# Altium schematic sheet MCU_Power_and_RF_Section.SchDoc
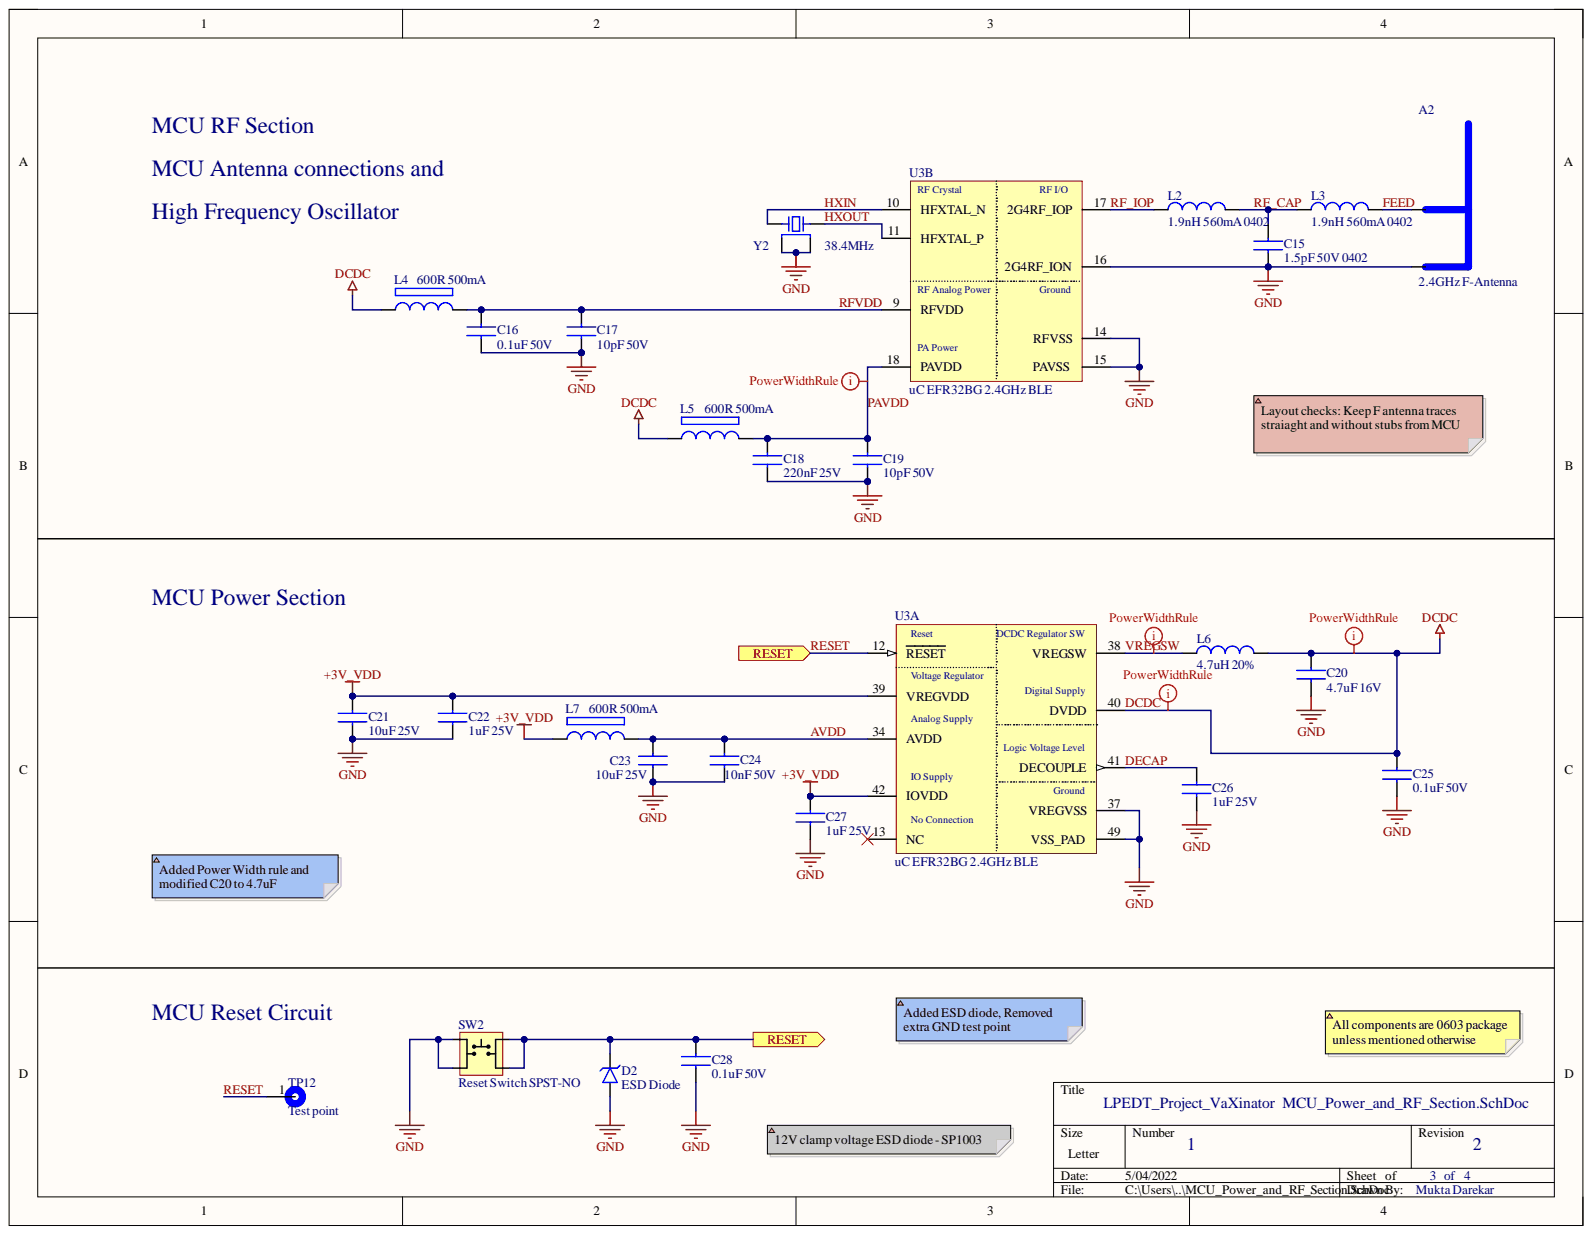
[diagram p0: MCU_Power_and_RF_Section.SchDoc]

## Parts
A2  2.4GHz F-Antenna  [F_ANT_FOOTPRINT]
C15  1.5pF 50V 0402  Capacitance: 1.5pF  Case Code (Imperial): 0402  [CAP_0402_1MM]
C16  0.1uF 50V  [CAP_0603_1608X09N]
C17  10pF 50V  [CAP_0603_1608X09N]
C18  220nF 25V  Capacitance: 220nF  [CAP_0603_1608X09N]
C19  10pF 50V  [CAP_0603_1608X09N]
C20  4.7uF 16V  Capacitance: 4.7uF  [CAP_0603_1608X09N]
C21  10uF 25V  [CAP_0603_1608X09N]
C22  1uF 25V  Capacitance: 1uF  Voltage Rating: 25V  [CAP_0603_1608X09N]
C23  10uF 25V  [CAP_0603_1608X09N]
C24  10nF 50V  Capacitance: 10nF  Voltage Rating: 50V  [CAP_0603_1608X09N]
C25  0.1uF 50V  [CAP_0603_1608X09N]
C26  1uF 25V  Capacitance: 1uF  Voltage Rating: 25V  [CAP_0603_1608X09N]
C27  1uF 25V  Capacitance: 1uF  [CAP_0603_1608X09N]
C28  0.1uF 50V  [CAP_0603_1608X09N]
D2  ESD Diode  [SOD882_DIODE_0402]
L2  1.9nH 560mA 0402  Case Code (Imperial): 0402  Max Current Rating: 560mA  [IND_RESC1005X35N]
L3  1.9nH 560mA 0402  Case Code (Imperial): 0402  Max Current Rating: 560mA  [IND_RESC1005X35N]
L4  600R 500mA  Current Rating: 500mA  Impedance: 600R  [BEADC1608X95N]
L5  600R 500mA  Current Rating: 500mA  Impedance: 600R  [BEADC1608X95N]
L6  4.7uH 20%  Inductance: 4.7uH  Tolerance: 20%  [INDC3030X15N]
L7  600R 500mA  Current Rating: 500mA  Impedance: 600R  [BEADC1608X95N]
SW2  Reset Switch SPST-NO  [TACT_SW_4PIN_SPST_450X450]
TP12  Test point  [TEST_POINT]  (no BOM)
U3  uC EFR32BG 2.4GHz BLE  [QFN48P700X700X90]  (parts A,B)
Y2  38.4MHz  [XTAL_CX2016DB16000D0WZRC1]

## Ports
RESET

## Nets
+3V_VDD: C21.1 C22.1 C27.1 L7.1 U3.39(VREGVDD) U3.42(IOVDD)
GND: A2.GND C15.2 C16.2 C17.2 C18.2 C19.1 C20.2 C21.2 C22.2 C23.2 C24.2 C25.2 C26.2 C27.2 C28.2 D2.2 SW2.1 SW2.4 U3.14(RFVSS) U3.15(PAVSS) U3.16(2G4RF_ION) U3.37(VREGVSS) U3.49(VSS_PAD) Y2.2 Y2.4
AVDD: C23.1 C24.1 L7.2 U3.34(AVDD)
DCDC: C20.1 C25.1 L4.1 L5.1 L6.2 U3.40(DVDD)
DECAP: C26.1 U3.41(DECOUPLE)
FEED: A2.FEED L3.2
HXIN: U3.10(HFXTAL_N) Y2.1
HXOUT: U3.11(HFXTAL_P) Y2.3
PAVDD: C18.1 C19.2 L5.2 U3.18(PAVDD)
RESET: C28.1 D2.1 SW2.2 SW2.3 TP12.1 U3.12(RESET) ; port RESET
RF_CAP: C15.1 L2.2 L3.1
RF_IOP: L2.1 U3.17(2G4RF_IOP)
RFVDD: C16.1 C17.1 L4.2 U3.9(RFVDD)
VREGSW: L6.1 U3.38(VREGSW)

Not connected: U3 13(NC)

## Library data of the parts
A2 = F_ANTENAA: Antenna; Component Kind: Standard; Library Name: LPEDT_Project.SchLib
C15 = GCM1555C1H1R5BA16D: CAP CER 1.5PF 50V 125C  0402 Cap Ceramic 1.5pF 50V C0G 0.1pF Pad SMD 0402 125C Automotive T/R; Manufacturer: Murata; Case Code (Metric): 1005; Case/Package: 0402; Category: Ceramic Capacitors; Component Kind: Standard; ComponentLink1Description: http://search.murata.co.jp/Ceramy/image/img/A01X/G101/ENG/GCM1555C1H1R5BA16-01.pdf?src-supplier=Digi-Key; ComponentLink1URL: http://search.murata.co.jp/Ceramy/image/img/A01X/G101/ENG/GCM1555C1H1R5BA16-01.pdf?src-supplier=Digi-Key; ComponentLink2Description: http://search.murata.co.jp/Ceramy/image/img/A01X/partnumbering_e_02.pdf?src-supplier=Digi-Key; ComponentLink2URL: http://search.murata.co.jp/Ceramy/image/img/A01X/partnumbering_e_02.pdf?src-supplier=Digi-Key; ComponentLink3Description: https://search.murata.co.jp/Ceramy/image/img/A01X/G101/ENG/GCM1555C1H1R5BA16-01.pdf?src-supplier=Digi-Key; ComponentLink3URL: https://search.murata.co.jp/Ceramy/image/img/A01X/G101/ENG/GCM1555C1H1R5BA16-01.pdf?src-supplier=Digi-Key; Library Name: LPEDT_Project.SchLib; Manufacturer Part Number: GCM1555C1H1R5BA16D; Max Operating Temperature: 125°C; Min Operating Temperature: -55°C; Mount: Surface Mount; Packaging: Tape and Reel; Pricing 1: 1=0.02300, 10000=0.02300 (USD); Ratings: AEC-Q200; RoHS: No; RoHS Compliant: No; Stock 1: 10000; Supplier 1: Newark; Voltage Rating: 50V
C16 C25 C28 = C0603C104M5RACTU: CAP CER 0.1UF 50V X7R 0603 KEMET; Capacitance: 100nF; Dielectric Material: Ceramic; Dissipation Factor: 2.5%; Tolerance: 20%; Case Code (Imperial): 0603; Case Code (Metric): 1608; Case/Package: 0603; Category: Ceramic Capacitors; Component Kind: Standard; ComponentLink1Description: http://components.arrow.com/part/dataview/40911037S8853915N7429?src-supplier=Arrow+Electronics; ComponentLink1URL: http://components.arrow.com/part/dataview/40911037S8853915N7429?src-supplier=Arrow+Electronics; ComponentLink2Description: https://www.verical.com/server-webapp/html/getDatasheet?partID=626892&src-supplier=Verical; ComponentLink2URL: https://www.verical.com/server-webapp/html/getDatasheet?partID=626892&src-supplier=Verical; ComponentLink3Description: http://www.farnell.com/datasheets/2746056.pdf?src-supplier=Farnell; ComponentLink3URL: http://www.farnell.com/datasheets/2746056.pdf?src-supplier=Farnell; ComponentLink4Description: http://components.arrow.com/part/dataview/0323_00313986?src-supplier=Arrow+Europe; ComponentLink4URL: http://components.arrow.com/part/dataview/0323_00313986?src-supplier=Arrow+Europe; ComponentLink5Description: http://www.mouser.com/ds/2/212/KEM_C1002_X7R_SMD-1102033.pdf?src-supplier=Mouser; ComponentLink5URL: http://www.mouser.com/ds/2/212/KEM_C1002_X7R_SMD-1102033.pdf?src-supplier=Mouser; ComponentLink6Description: http://www.alliedelec.com/m/d/6f3b5eb83d09db50ed28bdc730567b33.pdf?src-supplier=Allied+Electronics; ComponentLink6URL: http://www.alliedelec.com/m/d/6f3b5eb83d09db50ed28bdc730567b33.pdf?src-supplier=Allied+Electronics; ComponentLink7Description: http://www.farnell.com/datasheets/1828800.pdf?src-supplier=Newark; ComponentLink7URL: http://www.farnell.com/datasheets/1828800.pdf?src-supplier=Newark; ComponentLink8Description: http://capacitoredge.kemet.com/capedge2/DataSheet?pn=C0603C104M5RAC7867&src-supplier=Avnet; ComponentLink8URL: http://capacitoredge.kemet.com/capedge2/DataSheet?pn=C0603C104M5RAC7867&src-supplier=Avnet; ComponentLink9Description: http://www.farnell.com/datasheets/2315113.pdf?src-supplier=Newark; ComponentLink9URL: http://www.farnell.com/datasheets/2315113.pdf?src-supplier=Newark; ComponentLink10Description: https://api.kemet.com/component-edge/download/datasheet/C0603C104M5RACTU.pdf?src-supplier=Digi-Key; ComponentLink10URL: https://api.kemet.com/component-edge/download/datasheet/C0603C104M5RACTU.pdf?src-supplier=Digi-Key; ComponentLink11Description: https://www.verical.com/server-webapp/html/getDatasheet?partID=110138&src-supplier=Verical; ComponentLink11URL: https://www.verical.com/server-webapp/html/getDatasheet?partID=110138&src-supplier=Verical; ComponentLink12Description: http://www.farnell.com/datasheets/2746056.pdf?src-supplier=Element14; ComponentLink12URL: http://www.farnell.com/datasheets/2746056.pdf?src-supplier=Element14; ComponentLink13Description: http://www.farnell.com/datasheets/2746056.pdf?src-supplier=Newark; ComponentLink13URL: http://www.farnell.com/datasheets/2746056.pdf?src-supplier=Newark; ComponentLink14Description: http://capacitoredge.kemet.com/capedge2/DataSheet?pn=C0603C104M5RAC7867&src-supplier=Avnet+Asia; ComponentLink14URL: http://capacitoredge.kemet.com/capedge2/DataSheet?pn=C0603C104M5RAC7867&src-supplier=Avnet+Asia; Construction: Flat; Contact Plating: Tin,Matte; Depth: 0.8mm; Dielectric: X7R; Height: 0.8mm; Lead Pitch: 0.7mm; Length: 1.6mm; Library Name: LPEDT_Project_lib.IntLib; Manufacturer: KEMET; Manufacturer Part Number: C0603C104M5RACTU; Max Operating Temperature: 125°C; Min Operating Temperature: -55°C; Mount: Surface Mount,PCB; Package Quantity: 1; Packaging: Tape and Reel; Pricing 1: 1=0.10000, 10=0.03400, 50=0.01840, 100=0.01510, 500=0.01078, 1000=0.00847 (USD); Radiation Hardening: No; REACH SVHC: No SVHC; RoHS: Yes; Series: C; Supplier 1: Digi-Key; Termination: SMD/SMT; Thickness: 0.034inch; Voltage Rating: 50V; Voltage Rating (DC): 50V; Width: 0.031inch
C17 C19 = C0603C100J5RAC7867: CAP CER 10pF 50V X7R 0603 KEMET; Category: Ceramic Capacitors; Component Kind: Standard; Library Name: LPEDT_Project.SchLib; Manufacturer: KEMET; Manufacturer Part Number: C0603C100J5RAC7867; Pricing 1: 1=0.25000, 10=0.17400, 50=0.11580, 100=0.09840, 500=0.06944, 1000=0.06075 (USD); RoHS: Yes; RoHS Compliant: Yes; Supplier 1: Digi-Key
C18 = C0603C224K3RACAUTO: CAP CER 220nF (0.22uF) 25V X7R 0603 KEMET; Dielectric Material: Ceramic; Tolerance: 10%; Case Code (Imperial): 0603; Case Code (Metric): 1608; Case/Package: 0603; Category: Ceramic Capacitors; Component Kind: Standard; ComponentLink1Description: https://datasheet.ciiva.com/6254/datasheetpn-c0603c224k3racauto-6254707.pdf?src-supplier=Digi-Key; ComponentLink1URL: https://datasheet.ciiva.com/6254/datasheetpn-c0603c224k3racauto-6254707.pdf?src-supplier=Digi-Key; ComponentLink2Description: https://datasheet.ciiva.com/26948/getdatasheetpartid-785715-26948447.pdf?src-supplier=Verical; ComponentLink2URL: https://datasheet.ciiva.com/26948/getdatasheetpartid-785715-26948447.pdf?src-supplier=Verical; ComponentLink3Description: https://datasheet.ciiva.com/22699/1633156-22699737.pdf?src-supplier=Element14; ComponentLink3URL: https://datasheet.ciiva.com/22699/1633156-22699737.pdf?src-supplier=Element14; Contact Plating: Tin,Matte; Depth: 0.8mm; Dielectric: X7R; Length: 1.6mm; Library Name: LPEDT_Project_lib.IntLib; Manufacturer: KEMET; Manufacturer Part Number: C0603C224K3RACAUTO; Max Operating Temperature: 125°C; Min Operating Temperature: -55°C; Mount: Surface Mount; Package Quantity: 1; Packaging: Tape and Reel; Pricing 1: 1=0.19000, 10=0.13200, 50=0.07920, 100=0.06690, 500=0.04576, 1000=0.04048 (USD); Radiation Hardening: No; Ratings: AEC-Q200; REACH SVHC: No SVHC; RoHS: Yes; RoHS Compliant: Yes; Series: C; Stock 1: 7450; Supplier 1: Digi-Key; Termination: Wraparound; Thickness: 0.034inch; Voltage Rating: 25V; Voltage Rating (DC): 25V; Width: 0.031inch
C20 = GRM188R61C475KE11D: CAP CER 4.7UF 16V X5R 0603 MURATA; Tolerance: 10%; Case Code (Imperial): 0603; Case Code (Metric): 1608; Case/Package: 0603; Category: Ceramic Capacitors; Component Kind: Standard; ComponentLink1Description: http://www.mouser.com/ds/2/281/c02e-2905.pdf?src-supplier=Mouser; ComponentLink1URL: http://www.mouser.com/ds/2/281/c02e-2905.pdf?src-supplier=Mouser; ComponentLink2Description: http://search.murata.co.jp/Ceramy/image/img/A01X/G101/ENG/GRM188R61C475KE11-01.pdf?src-supplier=Digi-Key; ComponentLink2URL: http://search.murata.co.jp/Ceramy/image/img/A01X/G101/ENG/GRM188R61C475KE11-01.pdf?src-supplier=Digi-Key; ComponentLink3Description: http://search.murata.co.jp/Ceramy/image/img/A01X/partnumbering_e_01.pdf?src-supplier=Digi-Key; ComponentLink3URL: http://search.murata.co.jp/Ceramy/image/img/A01X/partnumbering_e_01.pdf?src-supplier=Digi-Key; ComponentLink4Description: http://psearch.en.murata.com/capacitor/product/GRM188R61C475KE11%23.pdf?src-supplier=Avnet; ComponentLink4URL: http://psearch.en.murata.com/capacitor/product/GRM188R61C475KE11%23.pdf?src-supplier=Avnet; Dielectric: X5R; Height: 0.8mm; Lead Free: Lead Free; Length: 0.063inch; Library Name: LPEDT_Project_lib.IntLib; Manufacturer: Murata; Manufacturer Part Number: GRM188R61C475KE11D; Max Operating Temperature: 85°C; Min Operating Temperature: -55°C; Mount: Surface Mount; Package Quantity: 4000; Packaging: Tape and Reel; Pricing 1: 1=0.36000, 10=0.24700, 50=0.17280, 100=0.14820, 500=0.11112, 1000=0.09384 (USD); Resistor Type: Ceramic; RoHS: Yes; RoHS Compliant: Yes; Stock 1: 258482; Supplier 1: Digi-Key; Termination: SMD/SMT; Thickness: 0.037inch; Voltage Rating: 16V; Voltage Rating (DC): 16V; Weight: 0.000222oz; Width: 0.031inch
C21 C23 = GRM188R61E106KA73D: CAP CER 10UF 25V X5R 0603 MURATA; Case/Package: 0603; Category: Ceramic Capacitors; Component Kind: Standard; ComponentLink1Description: https://search.murata.co.jp/Ceramy/image/img/A01X/G101/ENG/GRM188R61E106KA73-01.pdf?src-supplier=Digi-Key; ComponentLink1URL: https://search.murata.co.jp/Ceramy/image/img/A01X/G101/ENG/GRM188R61E106KA73-01.pdf?src-supplier=Digi-Key; Library Name: LPEDT_Project_lib.IntLib; Manufacturer: Murata; Manufacturer Part Number: GRM188R61E106KA73D; Pricing 1: 1=0.32000, 10=0.22500, 50=0.15740, 100=0.13500, 500=0.10122, 1000=0.08548 (USD); RoHS: Yes; RoHS Compliant: Yes; Stock 1: 517064; Supplier 1: Digi-Key
C22 C26 C27 = C0603C105M3RACTU: CAP CER 1UF 25V X7R 0603 KEMET; Dielectric Material: Ceramic; Dissipation Factor: 3.5%; Tolerance: 20%; Case Code (Imperial): 0603; Case Code (Metric): 1608; Case/Package: 0603; Category: Ceramic Capacitors; Component Kind: Standard; ComponentLink1Description: http://www.farnell.com/datasheets/2745887.pdf?src-supplier=Farnell; ComponentLink1URL: http://www.farnell.com/datasheets/2745887.pdf?src-supplier=Farnell; ComponentLink2Description: http://www.mouser.com/ds/2/212/KEM_C1002_X7R_SMD-1102033.pdf?src-supplier=Mouser; ComponentLink2URL: http://www.mouser.com/ds/2/212/KEM_C1002_X7R_SMD-1102033.pdf?src-supplier=Mouser; ComponentLink3Description: https://api.kemet.com/component-edge/download/datasheet/C0603C105M3RACTU.pdf?src-supplier=Digi-Key; ComponentLink3URL: https://api.kemet.com/component-edge/download/datasheet/C0603C105M3RACTU.pdf?src-supplier=Digi-Key; ComponentLink4Description: http://www.kemet.com/Lists/ProductCatalog/Attachments/46/KEM_C1003_C0G_SMD.pdf?src-supplier=Avnet+Asia; ComponentLink4URL: http://www.kemet.com/Lists/ProductCatalog/Attachments/46/KEM_C1003_C0G_SMD.pdf?src-supplier=Avnet+Asia; ComponentLink5Description: http://www.farnell.com/datasheets/2745887.pdf?src-supplier=Newark; ComponentLink5URL: http://www.farnell.com/datasheets/2745887.pdf?src-supplier=Newark; ComponentLink6Description: http://www.farnell.com/datasheets/2745887.pdf?src-supplier=Element14; ComponentLink6URL: http://www.farnell.com/datasheets/2745887.pdf?src-supplier=Element14; Contact Plating: Nickel,Tin,Matte; Dielectric: X7R; Height: 0.8mm; Length: 0.063inch; Library Name: LPEDT_Project_lib.IntLib; Manufacturer: KEMET; Manufacturer Part Number: C0603C105M3RACTU; Max Operating Temperature: 125°C; Min Operating Temperature: -55°C; Mount: Surface Mount; Package Quantity: 1; Packaging: Tape and Reel; Pricing 1: 1=0.43000, 10=0.29600, 50=0.20720, 100=0.17750, 500=0.13316, 1000=0.11244 (USD); REACH SVHC: No SVHC; RoHS: Yes; RoHS Compliant: Yes; Series: C; Stock 1: 12043; Supplier 1: Digi-Key; Termination: SMD/SMT; Thickness: 0.034inch; Voltage Rating (DC): 25V; Width: 0.8mm
  C27: Voltage Rating: 25V
C24 = C0603C103M5RACTU: CAP CER 10nF 50V X7R 0603 KEMET; Dielectric Material: Ceramic; Dissipation Factor: 2.5%; Tolerance: 20%; Case Code (Imperial): 0603; Case Code (Metric): 1608; Case/Package: 0603; Category: Ceramic Capacitors; Component Kind: Standard; ComponentLink1Description: https://datasheet.ciiva.com/7823/kem-c1002-x7r-smd-7823979.pdf?src-supplier=Element14; ComponentLink1URL: https://datasheet.ciiva.com/7823/kem-c1002-x7r-smd-7823979.pdf?src-supplier=Element14; ComponentLink2Description: https://datasheet.ciiva.com/7823/kem-c1002-x7r-smd-7823980.pdf?src-supplier=Element14; ComponentLink2URL: https://datasheet.ciiva.com/7823/kem-c1002-x7r-smd-7823980.pdf?src-supplier=Element14; Construction: Flat; Depth: 0.8mm; Dielectric: X7R; Height: 0.8mm; Lead Pitch: 0.7mm; Length: 1.6mm; Library Name: LPEDT_Project_lib.IntLib; Manufacturer: KEMET; Manufacturer Part Number: C0603C103M5RACTU; Max Operating Temperature: 125°C; Min Operating Temperature: -55°C; Mount: Surface Mount,PCB; Number of Pins: 2; Package Quantity: 1; Packaging: Tape and Reel; Pricing 1: 1=0.10000, 10=0.03000, 50=0.01640, 100=0.01350, 500=0.00962, 1000=0.00756 (USD); Radiation Hardening: No; REACH SVHC: No SVHC; RoHS: Yes; RoHS Compliant: Yes; Series: C; Stock 1: 452796; Supplier 1: Digi-Key; Termination: SMD/SMT; Thickness: 0.034inch; Voltage Rating (DC): 50V; Width: 0.8mm
D2 = SP1003-01ETG: TVS DIODE 5VWM 12VC SOD882; Manufacturer: Littelfuse; Breakdown Voltage: 5V; Capacitance: 30pF; Clamping Voltage: 12V; Diode Type: ZENER; Leakage Current: 100nA; Max Surge Current: 7A; Min Breakdown Voltage: 6V; Number of Channels: 1; Polarity: Bidirectional,Unidirectional; Power Line Protection: No; Test Current: 1mA; Category: TVS Diodes; Component Kind: Standard; ComponentLink1Description: https://datasheet.ciiva.com/4507/littelfuse-tvs-diode-array-spa-sp1003-221268-4507734.pdf?src-supplier=Mouser; ComponentLink1URL: https://datasheet.ciiva.com/4507/littelfuse-tvs-diode-array-spa-sp1003-221268-4507734.pdf?src-supplier=Mouser; ComponentLink2Description: https://datasheet.ciiva.com/16488/littelfuse-tvs-diode-array-spa-sp1003-16488471.pdf?src-supplier=Future+Electronics; ComponentLink2URL: https://datasheet.ciiva.com/16488/littelfuse-tvs-diode-array-spa-sp1003-16488471.pdf?src-supplier=Future+Electronics; ComponentLink3Description: https://datasheet.ciiva.com/1268/1854133-1268499.pdf?src-supplier=Element14; ComponentLink3URL: https://datasheet.ciiva.com/1268/1854133-1268499.pdf?src-supplier=Element14; ComponentLink4Description: https://datasheet.ciiva.com/330/littelfuse-tvs-diode-array-sp1003-datasheet-pdf-330595.pdf?src-supplier=Digi-Key; ComponentLink4URL: https://datasheet.ciiva.com/330/littelfuse-tvs-diode-array-sp1003-datasheet-pdf-330595.pdf?src-supplier=Digi-Key; ComponentLink5Description: https://datasheet.ciiva.com/330/littelfuse-tvs-diode-array-sp1003-datasheet-pdf-330596.pdf?src-supplier=Digi-Key; ComponentLink5URL: https://datasheet.ciiva.com/330/littelfuse-tvs-diode-array-sp1003-datasheet-pdf-330596.pdf?src-supplier=Digi-Key; ComponentLink6Description: https://datasheet.ciiva.com/330/littelfuse-tvs-diode-array-sp1003-datasheet-pdf-330594.pdf?src-supplier=Digi-Key; ComponentLink6URL: https://datasheet.ciiva.com/330/littelfuse-tvs-diode-array-sp1003-datasheet-pdf-330594.pdf?src-supplier=Digi-Key; Lead Free: Lead Free; Library Name: LPEDT_Project_lib.IntLib; Manufacturer Part Number: SP1003-01ETG; Max Operating Temperature: 85°C; Min Operating Temperature: -40°C; Mount: Surface Mount; Number of Elements: 1; Number of Pins: 2; Number of Unidirectional Channels: 1; Operating Supply Voltage: 5V; Package Quantity: 3000; Packaging: Tape and Reel; Peak Pulse Current: 7A; Pricing 1: 1=0.47000, 10=0.38000, 100=0.25870, 500=0.19404, 1000=0.14553, 2000=0.13340, 5000=0.12532 (USD); REACH SVHC: No SVHC; Resistor Type: Zener; Reverse Breakdown Voltage: 6V; Reverse Standoff Voltage: 5V; RoHS: Yes; RoHS Compliant: Yes; Series: Automotive, AEC-Q101, SP1003, SPA®; Stock 1: 77390; Supplier 1: Digi-Key; Termination: SMD/SMT
L2 L3 = 36401E1N9ATDF: Ind 1.9nH 560mA Ind High Frequency Chip Molded/Unshielded Thin Film 1.9nH 0.2nH 500MHz 13Q-Factor 560mA 0402 T/R; Manufacturer: TE Connectivity; DC Resistance (DCR): 350mR; Inductance: 0.0019uH; Lead/Base Style: SMD/SMT; Q Factor: 13; Self Resonant Frequency: 8GHz; Tolerance: 0.2%; Case Code (Metric): 1005; Case/Package: 0402; Category: Fixed Inductors; Component Kind: Standard; ComponentLink1Description: https://datasheet.ciiva.com/1131/eng-cd-1624108-ba-1131568.pdf?src-supplier=Farnell; ComponentLink1URL: https://datasheet.ciiva.com/1131/eng-cd-1624108-ba-1131568.pdf?src-supplier=Farnell; ComponentLink2Description: https://datasheet.ciiva.com/11800/0900766b80e1823e-11800596.pdf?src-supplier=RSComponents; ComponentLink2URL: https://datasheet.ciiva.com/11800/0900766b80e1823e-11800596.pdf?src-supplier=RSComponents; ComponentLink3Description: https://datasheet.ciiva.com/1129/1610600-1129220.pdf?src-supplier=Element14; ComponentLink3URL: https://datasheet.ciiva.com/1129/1610600-1129220.pdf?src-supplier=Element14; ComponentLink4Description: https://datasheet.ciiva.com/1129/1610600-1129221.pdf?src-supplier=Element14; ComponentLink4URL: https://datasheet.ciiva.com/1129/1610600-1129221.pdf?src-supplier=Element14; Depth: 0.55mm; ELV: Compliant; Height: 0.32mm; Length: 1mm; Library Name: LPEDT_Project.SchLib; Manufacturer Part Number: 36401E1N9ATDF; Max DC Current: 560mA; Max Frequency: 500MHz; Max Operating Temperature: 85°C; Min Operating Temperature: -40°C; Mount: Surface Mount; Number of Pins: 2; Package Quantity: 1000; Packaging: Tape and Reel; Pricing 1: 1=0.21000, 10=0.19200, 25=0.17080, 50=0.14520, 100=0.12820, 250=0.11752, 500=0.10684 (USD); Radiation Hardening: No; REACH SVHC: Unknown; Resistor Type: Thin Film; RoHS: Yes; RoHS Compliant: Yes; Series: 3640; Shielding: Unshielded; Stock 1: 735; Supplier 1: Digi-Key; Technology: THIN FILM; Termination: SMD/SMT; Test Frequency: 500MHz; Weight: 2.3E-05oz; Width: 0.5mm
L4 L5 L7 = BLM18AG601SN1D: Ferrite bead 0603 600Ω ±25% DCR=0.38Ω 500mA; Manufacturer: Murata; DC Resistance (DCR): 380mR; Frequency: 100MHz; Material: Steel,Ferrite; Number of Circuits: 1; Shielding: Unshielded; Case Code (Imperial): 0603; Case Code (Metric): 1608; Case/Package: 0603; Category: Inductors; Component Kind: Standard; ComponentLink1Description: https://datasheet.ciiva.com/19460/1844766-19460213.pdf?src-supplier=Jameco; ComponentLink1URL: https://datasheet.ciiva.com/19460/1844766-19460213.pdf?src-supplier=Jameco; ComponentLink2Description: https://datasheet.ciiva.com/41449/001172228-da-01-en-drossel-0603-600r-1-6x0-8mm-4000st-41449517.pdf?src-supplier=Conrad; ComponentLink2URL: https://datasheet.ciiva.com/41449/001172228-da-01-en-drossel-0603-600r-1-6x0-8mm-4000st-41449517.pdf?src-supplier=Conrad; ComponentLink3Description: https://datasheet.ciiva.com/29347/442309-da-01-en-chip-drossel-0603-600r-500ma-1-6x0-8mm-29347900.pdf?src-supplier=Conrad; ComponentLink3URL: https://datasheet.ciiva.com/29347/442309-da-01-en-chip-drossel-0603-600r-500ma-1-6x0-8mm-29347900.pdf?src-supplier=Conrad; ComponentLink4Description: https://datasheet.ciiva.com/1466/1756147-1466934.pdf?src-supplier=Element14; ComponentLink4URL: https://datasheet.ciiva.com/1466/1756147-1466934.pdf?src-supplier=Element14; ComponentLink5Description: https://datasheet.ciiva.com/1466/1756147-1466933.pdf?src-supplier=Element14; ComponentLink5URL: https://datasheet.ciiva.com/1466/1756147-1466933.pdf?src-supplier=Element14; ComponentLink6Description: https://datasheet.ciiva.com/1012/l0110s0100blm18a-1012018.pdf?src-supplier=Digi-Key; ComponentLink6URL: https://datasheet.ciiva.com/1012/l0110s0100blm18a-1012018.pdf?src-supplier=Digi-Key; ComponentLink7Description: https://datasheet.ciiva.com/1012/l0110s0100blm18a-1012017.pdf?src-supplier=Digi-Key; ComponentLink7URL: https://datasheet.ciiva.com/1012/l0110s0100blm18a-1012017.pdf?src-supplier=Digi-Key; ComponentLink8Description: https://datasheet.ciiva.com/1012/l0110s0100blm18a-1012019.pdf?src-supplier=Digi-Key; ComponentLink8URL: https://datasheet.ciiva.com/1012/l0110s0100blm18a-1012019.pdf?src-supplier=Digi-Key; ComponentLink9Description: https://datasheet.ciiva.com/16210/l0110s0100blm18a-16210006.pdf?src-supplier=Future+Electronics; ComponentLink9URL: https://datasheet.ciiva.com/16210/l0110s0100blm18a-16210006.pdf?src-supplier=Future+Electronics; ComponentLink10Description: https://datasheet.ciiva.com/3081/l0110s0100blm18a-3081613.pdf?src-supplier=Avnet; ComponentLink10URL: https://datasheet.ciiva.com/3081/l0110s0100blm18a-3081613.pdf?src-supplier=Avnet; Core Material: Ferrite; DC Current: 500mA; Depth: 0.8mm; Height: 0.8mm; Lead Free: Lead Free; Length: 1.6mm; Library Name: LPEDT_Project_lib.IntLib; Manufacturer Part Number: BLM18AG601SN1D; Max Current Rating: 500mA; Max DC Current: 500mA; Max Operating Temperature: 125°C; Military Standard: Not; Min Operating Temperature: -55°C; Number of Lines: 1; Number of Pins: 2; Package Quantity: 1; Packaging: Tape and Reel; Pricing 1: 1=0.10000, 10=0.07900, 25=0.07200, 50=0.06480, 100=0.04760, 250=0.03460, 500=0.03170, 1000=0.02738 (USD); Radiation Hardening: No; REACH SVHC: No SVHC; RoHS: Yes; RoHS Compliant: Yes; Supplier 1: Digi-Key; Termination: SMD/SMT; Test Frequency: 100MHz; Tolerance: 25%; Weight: 7.1E-05oz; Width: 0.8mm
L6 = LQH3NPN4R7MMEL: Ind Power Chip Shielded Wirewound 4.7uH 20% 1MHz Ferrite 1.7A 1212 Emboss T/R; Manufacturer: Murata; Current Rating: 1A; DC Resistance (DCR): 120mR; Saturation Current: 1.3A; Self Resonant Frequency: 40MHz; Case Code (Imperial): 1212; Case Code (Metric): 3030; Case/Package: 1212; Category: Fixed Inductors; Component Kind: Standard; ComponentLink1Description: http://search.murata.co.jp/Ceramy/image/img/P02/JELF243A-0153.pdf?src-supplier=Digi-Key; ComponentLink1URL: http://search.murata.co.jp/Ceramy/image/img/P02/JELF243A-0153.pdf?src-supplier=Digi-Key; Core Material: Ferrite; Library Name: LPEDT_Project_lib.IntLib; Manufacturer Part Number: LQH3NPN4R7MMEL; Max Operating Temperature: 105°C; Min Operating Temperature: -40°C; Mount: Surface Mount; Packaging: Cut Tape; Pricing 1: 1=0.36000, 10=0.32000, 25=0.28040, 50=0.24020, 100=0.22020, 250=0.20020, 500=0.18818, 1000=0.14414 (USD); Resistor Type: Wirewound; RoHS: Yes; RoHS Compliant: Yes; Shielding: Shielded; Stock 1: 3543; Supplier 1: Digi-Key; Test Frequency: 1MHz
SW2 = TL3305AF160QG: TL3305A Series SPST 12 V 4.5 x 4.5 mm 160 gf Top Actuated SMT Tactile Switch; Manufacturer: E-Switch; Actuator Type: Standard; Illumination Type: Non-Illuminated; Mechanical Life: 500000Cycles; Operating Force: 160gf; Switch Function: Off-Mom; Switch Travel: 0.2mm; Category: Tactile Switches; Component Kind: Standard; ComponentLink1Description: http://www.farnell.com/datasheets/2554191.pdf?src-supplier=Farnell; ComponentLink1URL: http://www.farnell.com/datasheets/2554191.pdf?src-supplier=Farnell; ComponentLink2Description: http://spec_sheets.e-switch.com/specs/P010468.pdf?src-supplier=Digi-Key; ComponentLink2URL: http://spec_sheets.e-switch.com/specs/P010468.pdf?src-supplier=Digi-Key; ComponentLink3Description: http://www.farnell.com/datasheets/2554191.pdf?src-supplier=Newark; ComponentLink3URL: http://www.farnell.com/datasheets/2554191.pdf?src-supplier=Newark; Lead Free: Lead Free; Library Name: LPEDT_Project_lib.IntLib; Manufacturer Part Number: TL3305AF160QG; Max Operating Temperature: 70°C; Min Operating Temperature: -20°C; Mount: Surface Mount; Packaging: Tape and Reel; Pricing 1: 1=0.21000, 10=0.20500, 25=0.19440, 100=0.17600, 250=0.16048, 500=0.14918, 1000=0.12726 (USD); RoHS: Yes; RoHS Compliant: Yes; Stock 1: 31081; Supplier 1: Digi-Key; Termination: Gull Wing; Throw Configuration: SPST
TP12 = TEST_POINT: Test point - Top layer 1.5mm round Pad; Library Name: LPEDT_Project.SchLib
U3 = EFR32BG13P732F512GM48-D: Blue Gecko QFN48 2.4 G 19 dB BLE/Proprietary 512 kB 64 kB(RAM) 31GPIO; Manufacturer: Silicon Labs; Category: RF Receivers, Transceivers; Component Kind: Standard; ComponentLink1Description: http://www.farnell.com/datasheets/2813683.pdf?src-supplier=Farnell; ComponentLink1URL: http://www.farnell.com/datasheets/2813683.pdf?src-supplier=Farnell; Library Name: LPEDT_Project.SchLib; Manufacturer Part Number: EFR32BG13P732F512GM48-D; Pricing 1: 1=8.42000, 10=7.72000, 25=7.01800, 80=6.31625, 230=5.78987, 440=5.26350, 945=4.78499 (USD); RoHS: Yes; Supplier 1: Digi-Key
Y2 = CX2016DB38400F0FSRC1: Crystal 38.4MHz 4-Pin SMD T/R; Manufacturer: Kyocera AVX; Category: Crystals and Oscillators; Component Kind: Standard; Library Name: LPEDT_Project.SchLib; Manufacturer Part Number: CX2016DB38400F0FSRC1; Pricing 1: 1=1.05000, 10=0.92900, 50=0.86860, 100=0.76760, 500=0.72720, 1000=0.60600 (USD); RoHS: No; Stock 1: 2086; Supplier 1: Digi-Key
